# Altium schematic sheet 07-General I2C Devices.SchDoc("07-General I2C Devices")
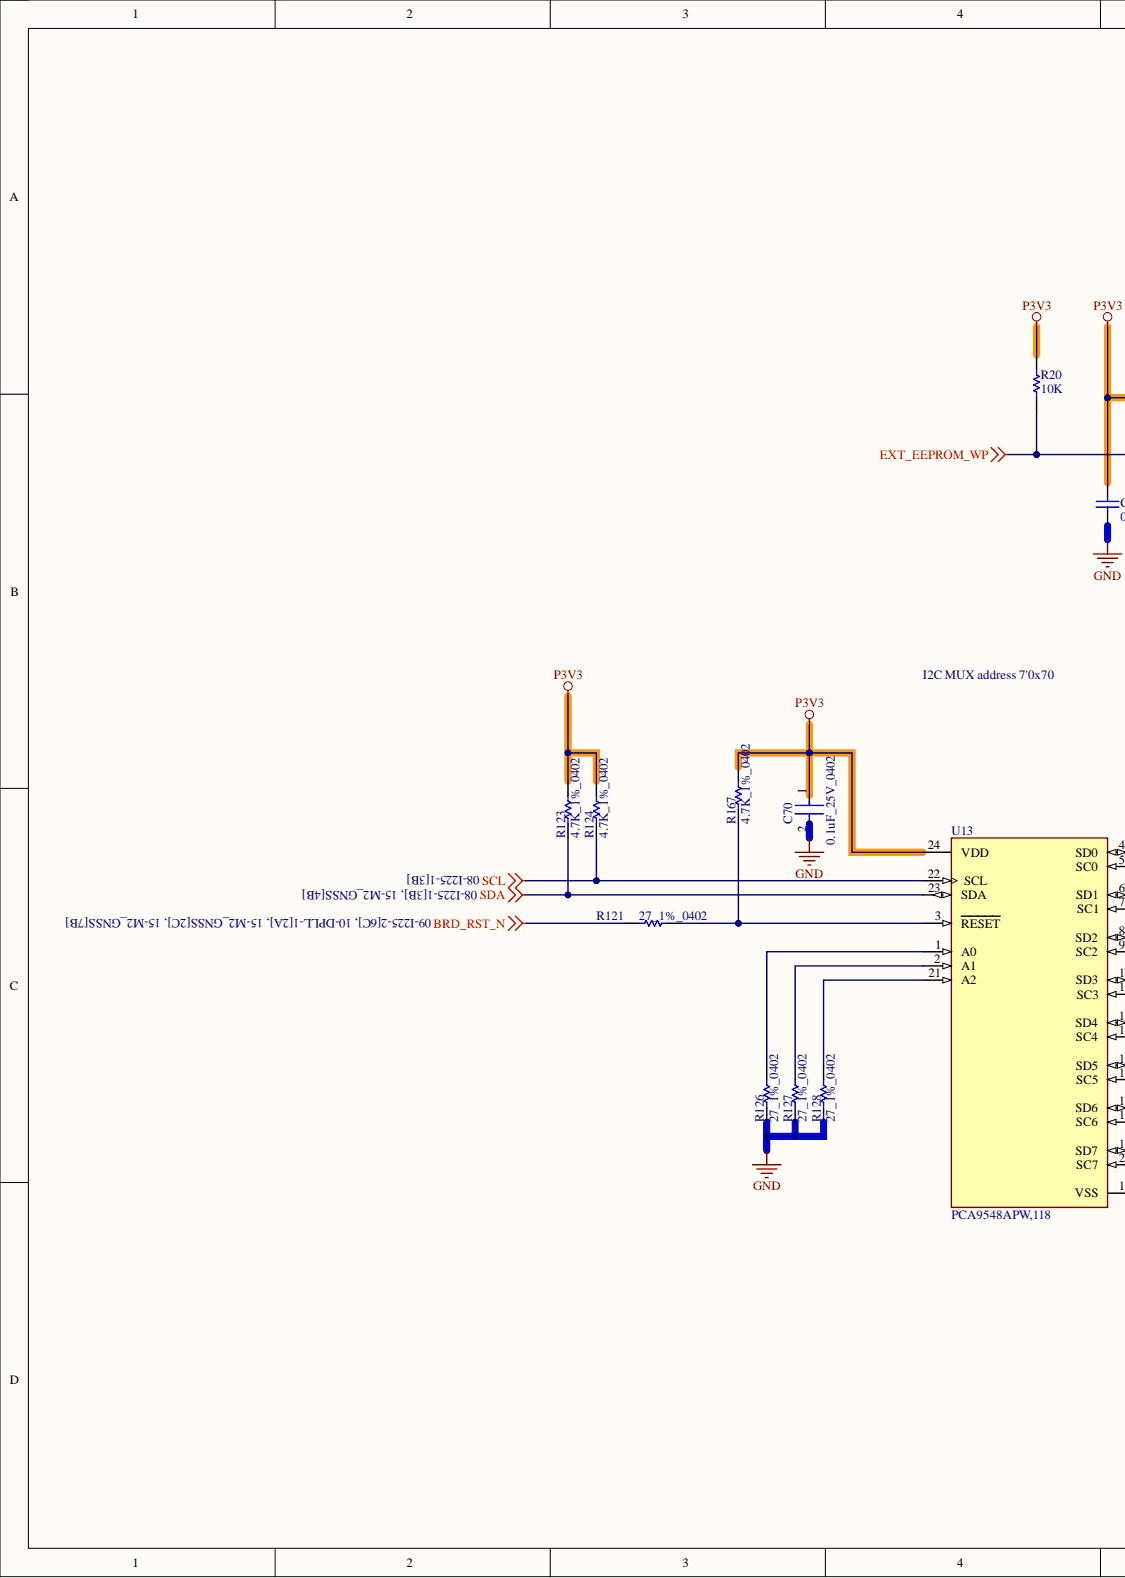
[diagram p0: 07-General I2C Devices.SchDoc, left]
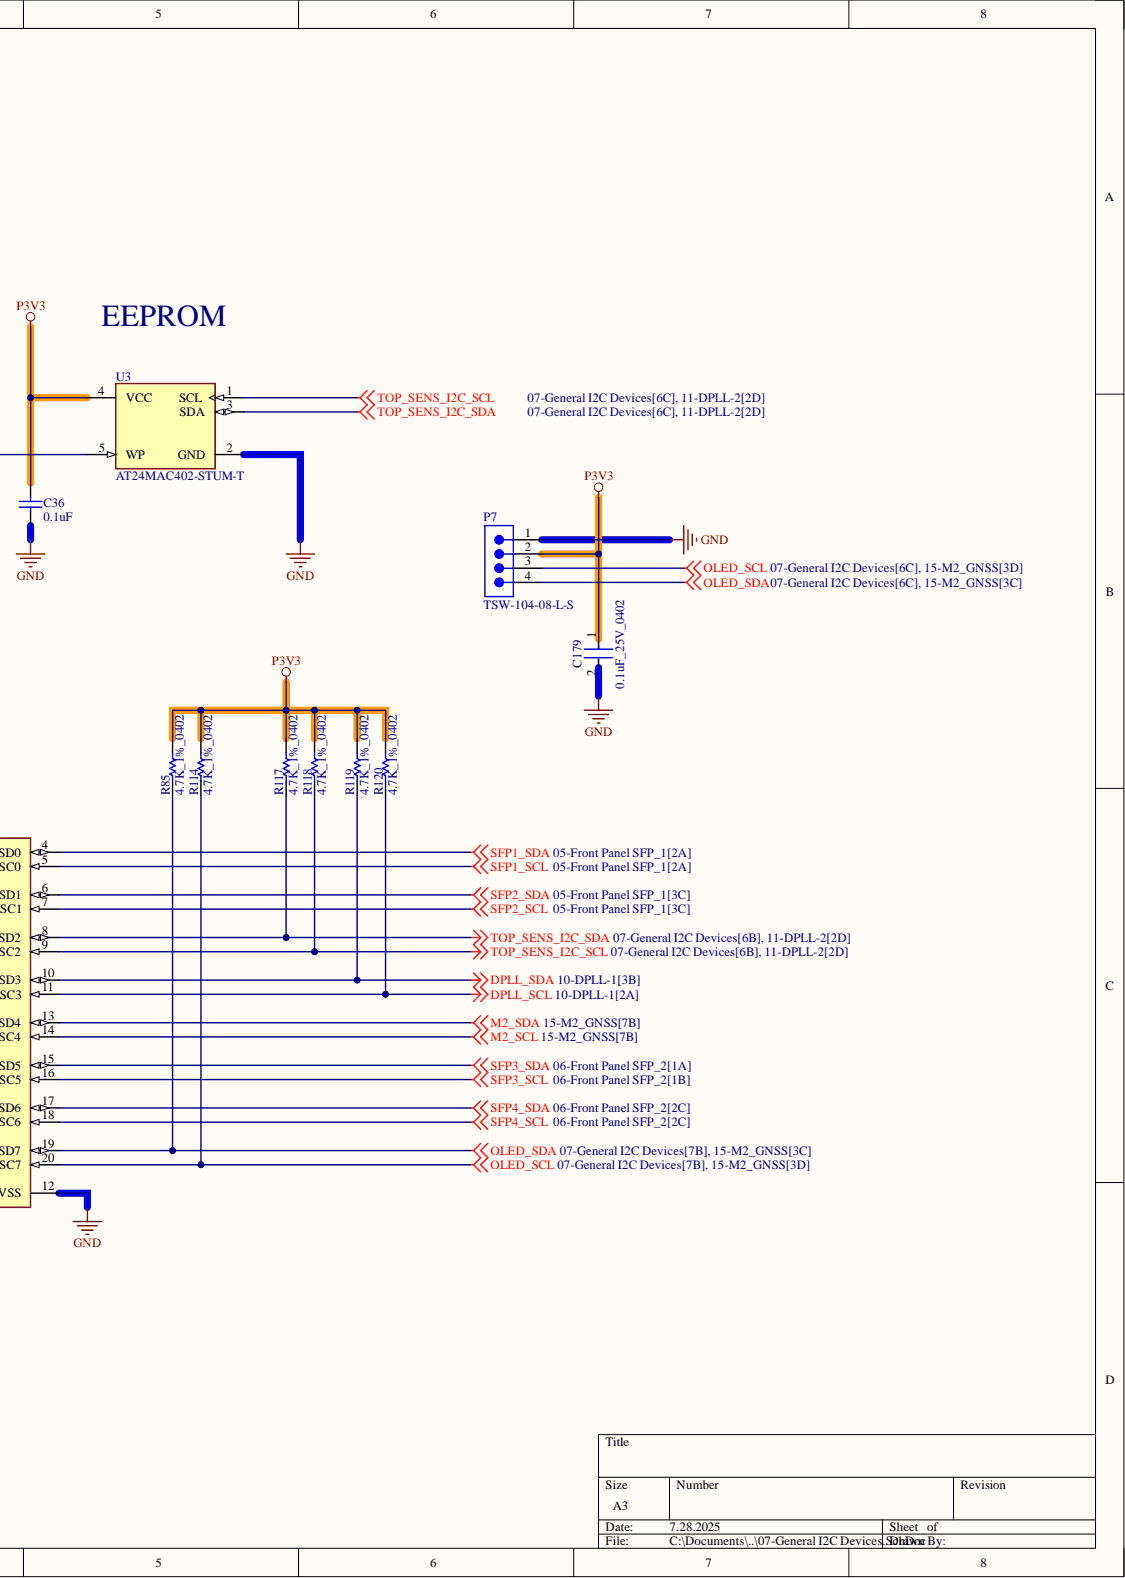
[diagram p1: 07-General I2C Devices.SchDoc, right]

## Parts
C36  0.1uF  [CAPC1608X87X45ML20T05]
C70  0.1uF_25V_0402  [FP-0402-L_1_0_1-W_0_5_0_1-IPC_C]
C179  0.1uF_25V_0402  [FP-0402-L_1_0_1-W_0_5_0_1-IPC_C]
P7  TSW-104-08-L-S  [SMTC-TSW-104-08-X-S]
R20  10K  [RESC1608X55X30LL15T20]
R85  4.7K_1%_0402  [RESC1005X40X25NL10T10]
R114  4.7K_1%_0402  [RESC1005X40X25NL10T10]
R117  4.7K_1%_0402  [RESC1005X40X25NL10T10]
R118  4.7K_1%_0402  [RESC1005X40X25NL10T10]
R119  4.7K_1%_0402  [RESC1005X40X25NL10T10]
R120  4.7K_1%_0402  [RESC1005X40X25NL10T10]
R121  27_1%_0402  [RESC1005X40X25NL05T05]
R123  4.7K_1%_0402  [RESC1005X40X25NL10T10]
R124  4.7K_1%_0402  [RESC1005X40X25NL10T10]
R126  27_1%_0402  [RESC1005X40X25NL05T05]
R127  27_1%_0402  [RESC1005X40X25NL05T05]
R128  27_1%_0402  [RESC1005X40X25NL05T05]
R167  4.7K_1%_0402  [RESC1005X40X25NL10T10]
U3  AT24MAC402-STUM-T  [FP-5TS1-IPC_C]
U13  PCA9548APW,118  [FP-SOT355-1-MFG]

## Nets
BRD_RST_N: R121.1
DPLL_SCL: R120.1 U13.11(SC3)
DPLL_SDA: R119.1 U13.10(SD3)
EXT_EEPROM_WP: R20.1 U3.5(WP)
GND: C36.1 C70.2 C179.2 P7.1 R126.1 R127.1 R128.1 U3.2(GND) U13.12(VSS)
M2_SCL: U13.14(SC4)
M2_SDA: U13.13(SD4)
OLED_SCL: P7.3 R114.1 U13.20(SC7)
OLED_SDA: P7.4 R85.1 U13.19(SD7)
P3V3: C36.2 C70.1 C179.1 P7.2 R20.2 R85.2 R114.2 R117.2 R118.2 R119.2 R120.2 R123.2 R124.2 R167.2 U3.4(VCC) U13.24(VDD)
SCL: R124.1 U13.22(SCL)
SDA: R123.1 U13.23(SDA)
SFP1_SCL: U13.5(SC0)
SFP1_SDA: U13.4(SD0)
SFP2_SCL: U13.7(SC1)
SFP2_SDA: U13.6(SD1)
SFP3_SCL: U13.16(SC5)
SFP3_SDA: U13.15(SD5)
SFP4_SCL: U13.18(SC6)
SFP4_SDA: U13.17(SD6)
TOP_SENS_I2C_SCL: R118.1 U3.1(SCL) U13.9(SC2)
TOP_SENS_I2C_SDA: R117.1 U3.3(SDA) U13.8(SD2)
NetR121_2: R121.2 R167.1 U13.3(RESET)
NetR126_2: R126.2 U13.1(A0)
NetR127_2: R127.2 U13.2(A1)
NetR128_2: R128.2 U13.21(A2)

## Library data of the parts
C36 = CMP-2006-00003-1: C0603X104K5RACAUTO; Manufacturer: Kemet; Component Kind: Standard; Library Name: Altium Content Vault; Part Number: C0603X104K5RACAUTO
C70 C179 = CMP-2008-02519-2: General Purpose Ceramic Capacitor, 0402, 100nF, 10%, X7R, 15%, 25V; Capacitance: 100nF; Case Code (Imperial): 0402; Case Code (Metric): 1005; Case/Package: 0402; Component Kind: Standard; Construction: Flat; Depth: 0.5mm; Dielectric: X7R; Lead Free: Lead Free; Length: 1mm; Library Name: Altium Content Vault; Max Operating Temperature: 125°C; Min Operating Temperature: -55°C; Mount: Surface Mount; Number of Pins: 2; Package Quantity: 1; Packaging: Tape and Reel; Radiation Hardening: No; REACH SVHC: No SVHC; RoHS Compliant: Yes; Series: -; Termination: SMD/SMT; Thickness: 0.022inch; Tolerance: 10%; Voltage: 25V; Voltage Rating: 25V; Voltage Rating (DC): 25V; Width: 0.02inch
P7 = CMP-1024-00358-1: 0.025" SQ Post Header, Through-hole, Vertical, -55 to 125 degC, 2.54 mm Pitch, 4-Pin, Male, RoHS; Manufacturer: Samtec; Pitch: 2.54 mm; Component Kind: Standard; ComponentLink1Description: Manufacturer URL; ComponentLink1URL: http://www.samtec.com; ComponentLink2Description: Datasheet; ComponentLink2URL: http://www.samtec.com/documents/webfiles/pdf/TSW_TH.PDF; ComponentLink3Description: Package Specification; ComponentLink3URL: http://www.samtec.com/documents/webfiles/cpdf/TSW-XXX-XX-XXX-X-XX-XXX-MKT.pdf; DatasheetVersion: F-214; Library Name: Altium Content Vault; Mounting Technology: Through-hole; Orientation: Vertical; PackageDescription: .025[0.64] SQ. Post Terminal Strip Assembly; PackageReference: TSW-104-08-X-S; PackageVersion: DM, 8/1988; PartNumber: TSW-104-08-L-S; RoHS: True; Temperature Range: -55 to 125 degC
R20 = CMP-2000-06990-1: Chip Resistor, 10 KOhm, +/- 1%, 0.1 W, -55 to 155 degC, 0603 (1608 Metric), RoHS, Tape and Reel RMCF0603FT10K0; Manufacturer: Stackpole-Electronics-Inc.; Power (Watts): 0.1W,1/10W; Temperature Coefficient: ±100ppm/°C; Category: Resistors; Component Kind: Standard; Composition: ThickFilm; Datasheet URL: https://www.seielect.com/Catalog/SEI-RMCF_RMCP.pdf; Datasheet Version: 01/2017; Family: ChipResistor-SurfaceMount; Features: AutomotiveAEC-Q200; Height: 0.022"(0.55mm); Library Name: Altium Content Vault; Manufacturer URL: https://www.seielect.com/; Mounting Technology: SurfaceMount; Number of Terminations: 2; Operating Temperature: -55°C~155°C; Package / Case: 0603(1608Metric); Package Description: 2-Pin Surface Mount Device, Body 1.55 x 0.8 mm; Package Reference: 0603; Packaging: CutTape(CT); Resistance (Ohms): 10k; Series: RMCF; Size / Dimension: 0.061"Lx0.031"W(1.55mmx0.80mm); Supplier Device Package: 0603; Tolerance: ±1%
R85 R114 R117-R120 R123 R124 R167 = CMP-2002-05217-1: Manufacturer: Bourns; Part Number: CR0402-FX-4701GLF; Component Kind: Standard; Library Name: Altium Content Vault
R121 R126-R128 = CMP-2002-08434-1: Manufacturer: Vishay Dale; Part Number: CRCW040227R0FKED; Component Kind: Standard; Library Name: Altium Content Vault
U3 = CMP-06313-000011-1: IC EEPROM 2K I2C 1MHZ SOT23-5; Manufacturer: Microchip; Access Time: 0.55us; Frequency: 1000kHz; Interface: I2C,2-Wire; Max Supply Voltage: 5.5V; Memory Size: 2Kbits; Min Supply Voltage: 1.7V; Nominal Supply Current: 0.4-2mA; Standoff Height: 0mm; Automotive: No; Category: IC; Component Kind: Standard; ComponentLink2Description: Datasheet; ComponentLink2URL: http://ww1.microchip.com/downloads/en/DeviceDoc/Atmel-8807-SEEPROM-AT24MAC402-602-Datasheet.pdf; Device Class L1: Integrated Circuits (ICs); Device Class L2: Memory; Device Class L3: EEPROM; Digikey Description: IC EEPROM 2K I2C 1MHZ SOT23-5; Height: 1mm; Ipc Land Pattern Name: SOT95P280X100-5; Lead Free: Yes; Library Name: Altium Content Vault; Manufacturer Part Number: AT24MAC402-STUM-T; Memory Type: EEPROM; Mouser Description: EEPROM Serial-I2C 2K-bit 256 x 8 1.8V/2.5V/3.3V/5V 5-Pin SOT-23 T/R; Octopart: https://octopart.com/at24mac402-stum-t-microchip-77758112; Package: 5TS1; RoHS: TRUE; Temperature: -40°C to +85°C; Temperature Range High: +85°C; Temperature Range Low: -40°C
U13 = CMP-2000-06880-2: IC INTERFACE SPECIALIZED 24TSSOP; Bandwidth: 400kHz; Interface: I2C; Logic Function: Multiplexer; Low Level Output Current: 9mA; Max Power Dissipation: 400mW; Max Supply Voltage: 5.5V; Min Supply Voltage: 2.3V; Nominal Supply Current: 100mA; Number of Channels: 16; Number of Inputs: 8; Number of Outputs: 1; On-State Resistance: 24R; Operating Supply Current: 65uA; Propagation Delay: 0.3ns; Turn-Off Delay Time: 300ns; Case/Package: TSSOP; Component Kind: Standard; Contact Plating: Gold; Lead Free: Lead Free; Library Name: Altium Content Vault; Max Frequency: 400kHz; Max Operating Temperature: 85°C; Min Operating Temperature: -40°C; Mount: Surface Mount; Number of Pins: 24; Package Quantity: 2500; Packaging: Tape and Reel; Power Dissipation: 400mW; Quiescent Current: 65uA; Radiation Hardening: No; Turn-On Delay Time: 300ns
